# Altium schematic sheet DVT-Discrete-1.SchDoc(DVT-Discrete-1)
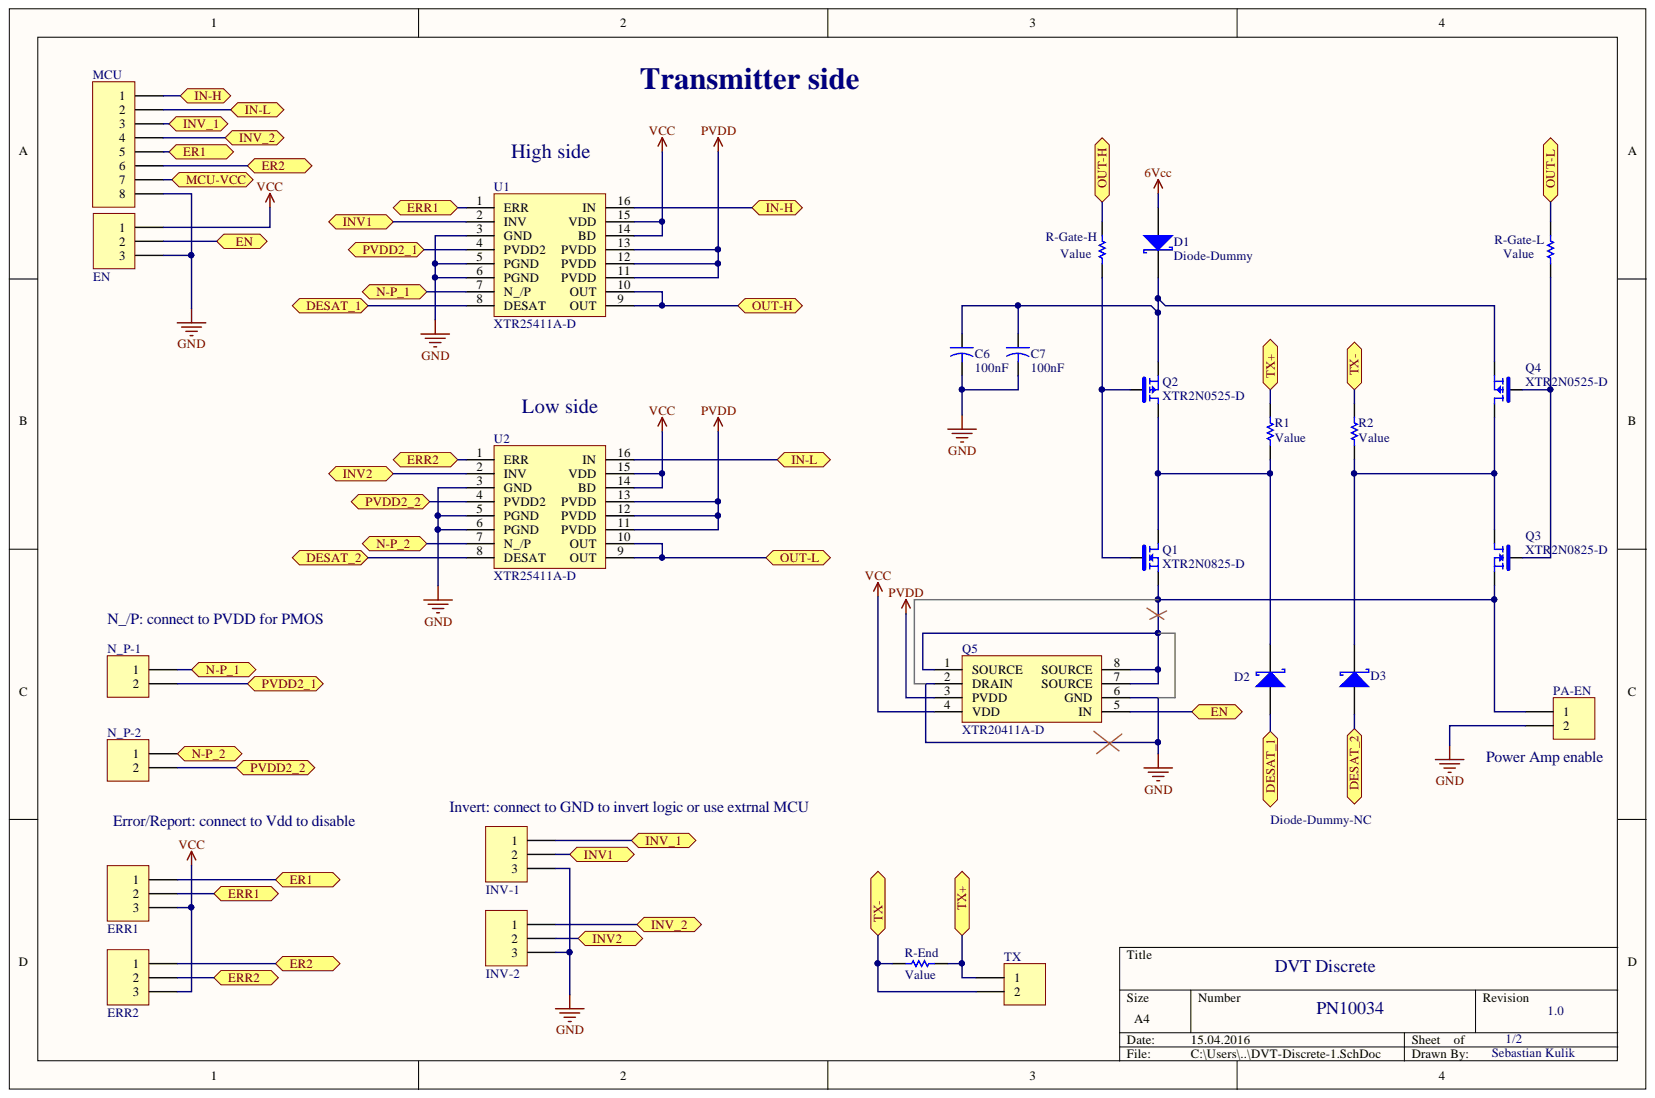
[diagram p0: DVT-Discrete-1.SchDoc]

## Parts
C6  value 100nF  [Dummy-10mm]  (hidden comment: Capacitor-Dummy-THT)
C7  value 100nF  [Dummy-10mm]  (hidden comment: Capacitor-Dummy-THT)
D1  Diode-Dummy  [DO-15-Dummy]
D2  Diode-Dummy-NC  [DO-15-Dummy]
D3  Diode-Dummy  [DO-15-Dummy]
EN  Plug_3_90_2.54  [HDR1X3]  (value not drawn)
ERR1  Plug_3_90_2.54  [HDR1X3]  (value not drawn)
ERR2  Plug_3_90_2.54  [HDR1X3]  (value not drawn)
INV-1  Plug_3_90_2.54  [HDR1X3]  (value not drawn)
INV-2  Plug_3_90_2.54  [HDR1X3]  (value not drawn)
MCU  Plug_08_2.54  [HDR1X8_2.54]  (value not drawn)
N_P-1  Plug_2_90_2.54  [HDR1X2]  (value not drawn)
N_P-2  Plug_2_90_2.54  [HDR1X2]  (value not drawn)
PA-EN  Plug_2_90_2.54  [HDR1X2]  (value not drawn)
Q1  XTR2N0825-D  [DIP-8-Ceramic-HT]
Q2  XTR2N0525-D  [DIP-8-Ceramic-HT]
Q3  XTR2N0825-D  [DIP-8-Ceramic-HT]
Q4  XTR2N0525-D  [DIP-8-Ceramic-HT]
Q5  XTR20411A-D  [DIP-8-Ceramic-HT]
R1  Resistor-Dummy-THT  [Dummy-15mm]  (value not drawn)
R2  Resistor-Dummy-THT  [Dummy-15mm]  (value not drawn)
R-End  Resistor-Dummy-THT  [Dummy-10mm]  (value not drawn)
R-Gate-H  Resistor-Dummy-THT  [Dummy-10mm]  (value not drawn)
R-Gate-L  Resistor-Dummy-THT  [Dummy-10mm]  (value not drawn)
TX  PHOENIX_1715721  [Phoenix-2-5_08]  (value not drawn)
U1  XTR25411A-D  [DIP-16-Ceramic-HT]
U2  XTR25411A-D  [DIP-16-Ceramic-HT]

## Ports
DESAT_1, DESAT_2, EN, ER1, ER2, ERR1, ERR2, IN-H, IN-L, INV1, INV2, INV_1, INV_2, MCU-VCC, N-P_1, N-P_2, OUT-H, OUT-L, PVDD2_1, PVDD2_2, TX+, TX-

## Nets
6VCC: D1.2
GND: C6.2 C7.2 EN.3 INV-1.3 INV-2.3 MCU.8 PA-EN.2 Q5.2(DRAIN) Q5.6(GND) U1.3(GND) U1.5(PGND) U1.6(PGND) U2.3(GND) U2.5(PGND) U2.6(PGND)
PVDD: Q5.3(PVDD) U1.11(PVDD) U1.12(PVDD) U1.13(PVDD) U2.11(PVDD) U2.12(PVDD) U2.13(PVDD)
VCC: EN.1 ERR1.3 ERR2.3 Q5.4(VDD) U1.14(BD) U1.15(VDD) U2.14(BD) U2.15(VDD)
DESAT_1: D2.2 U1.8(DESAT) ; port DESAT_1
DESAT_2: D3.2 U2.8(DESAT) ; port DESAT_2
EN: EN.2 Q5.5(IN) ; port EN
ER1: ERR1.1 MCU.5 ; port ER1
ER2: ERR2.1 MCU.6 ; port ER2
ERR1: ERR1.2 U1.1(ERR) ; port ERR1
ERR2: ERR2.2 U2.1(ERR) ; port ERR2
IN-H: MCU.1 U1.16(IN) ; port IN-H
IN-L: MCU.2 U2.16(IN) ; port IN-L
INV1: INV-1.2 U1.2(INV) ; port INV1
INV2: INV-2.2 U2.2(INV) ; port INV2
INV_1: INV-1.1 MCU.3 ; port INV_1
INV_2: INV-2.1 MCU.4 ; port INV_2
MCU-VCC: MCU.7 ; port MCU-VCC
N-P_1: N_P-1.1 U1.7(N_/P) ; port N-P_1
N-P_2: N_P-2.1 U2.7(N_/P) ; port N-P_2
OUT-H: R-Gate-H.2 U1.9(OUT) U1.10(OUT) ; port OUT-H
OUT-L: R-Gate-L.2 U2.9(OUT) U2.10(OUT) ; port OUT-L
PVDD2_1: N_P-1.2 U1.4(PVDD2) ; port PVDD2_1
PVDD2_2: N_P-2.2 U2.4(PVDD2) ; port PVDD2_2
NetC6_1: C6.1 C7.1 D1.1 Q2.1 Q4.1
NetD2_1: D2.1 Q1.5 Q2.5 R1.1
NetD3_1: D3.1 Q3.5 Q4.5 R2.1
NetPA-EN_1: PA-EN.1 Q1.1 Q3.1 Q5.1(SOURCE) Q5.7(SOURCE) Q5.8(SOURCE)
NetQ1_4: Q1.4 Q2.4 R-Gate-H.1
NetQ3_4: Q3.4 Q4.4 R-Gate-L.1
NetR1_2: R1.2 R-End.2 TX.1
NetR2_2: R2.2 R-End.1 TX.2

## Library data of the parts
C6 C7 = Capacitor-Dummy-THT: Deliverer PartNr: 699-5027; Manufacturer: AVX; Manufacturer PartNr: SR205E104MARTR; Deliverer: RS; Component Kind: Standard; Description: Capacitor-Dummy-THT; Library Name: Capacitors.IntLib; PackageDescription: -; Part Description: Capacitor-Dummy-THT; Published: 08.12.2015; Signal Integrity: Cap; Simulation: CAP; TDE-PN: -; Publisher: Sebastian Kulik
D1-D3 = Diode-Dummy: Deliverer PartNr: 906-6762; Manufacturer: COMCHIP TECHNOLOGY; Manufacturer PartNr: SB5100E-G; Deliverer: RS; Component Kind: Standard; Description: Diode-Dummy; Library Name: Discrete.IntLib; PackageDescription: DO-201AD; Published: 09.12.2015; Simulation: 18TQ045; TDE-PN: -; Publisher: Sebastian Kulik
EN ERR1 ERR2 INV-1 INV-2 = Plug_3_90_2.54: Plug,-3-Pin; Deliverer PartNr: 2356177; Manufacturer: WE; Manufacturer PartNr: 61300311021; Deliverer: Farnell; TDE-PN: CON0011; Component Kind: Standard; Library Name: Connectors.IntLib; Publisher: Sebastian Kulik
MCU = Plug_08_2.54: Plug, 08-Pin; Deliverer PartNr: 61300811121; Deliverer: WE; TDE-PN: CON0035; Component Kind: Standard; LatestRevisionDate: 11.11.2014; LatestRevisionNote: Re-released for DXP Platform.; Library Name: Connectors.IntLib; Manufacturer: WE; Manufacturer PartNr: 61300811121; Signal Integrity: Connector; Publisher: Sebastian Kulik
N_P-1 N_P-2 PA-EN = Plug_2_90_2.54: Plug, 2-Pin; Deliverer PartNr: 2356176; Manufacturer: WE; Manufacturer PartNr: 61300211021; Deliverer: Farnell; TDE-PN: CON0010; Component Kind: Standard; LatestRevisionDate: 14.05.2014; LatestRevisionNote: Re-released for DXP Platform.; Library Name: Connectors.IntLib; Signal Integrity: Connector; Publisher: Sebastian Kulik
Q1 Q3 = XTR2N0825-D: N-FET, 80V@ 4A; Manufacturer: TI; Deliverer: ProTEc; TDE-PN: DIS0043; Component Kind: Standard; Deliverer PartNr: XTR2N0825-D; Library Name: Discrete.IntLib; Manufacturer PartNr: XTR2N0825-D; PackageDescription: DIP-8-Ceramic-HT; Published: 09.12.2015; Publisher: Sebastian Kulik
Q2 Q4 = XTR2N0525-D: P-FET, 50V@ 4A; Manufacturer: TI; Deliverer: ProTEc; TDE-PN: DIS0044; Component Kind: Standard; Deliverer PartNr: XTR2N0525-D; Library Name: Discrete.IntLib; Manufacturer PartNr: XTR2N0525-D; PackageDescription: DIP-8-Ceramic-HT; Published: 09.12.2015; Publisher: Sebastian Kulik
Q5 = XTR20411A-D: Gate driver; Manufacturer: X-Rel; Deliverer: ProTec; TDE-PN: IC0068; Component Kind: Standard; Deliverer PartNr: XTR20411A-D; Library Name: ICs.IntLib; Manufacturer PartNr: XTR20411A-D; PackageDescription: DIP-8-Ceramic-HT; Published: 08.12.2015; Publisher: Sebastian Kulik
TX = 2Pol_1715721: Phoenix, 2 pol, 5.08mm, 22A, 715721; Deliverer PartNr: 193-0564; Deliverer: RS; TDE-PN: CON0008; Component Kind: Standard; LatestRevisionDate: 02.05.2014; LatestRevisionNote: Re-released for DXP Platform.; Library Name: Connectors.IntLib; Manufacturer: Phoenix; Manufacturer PartNr: 1715721; PackageDescription: Phoenix-2-5_08; Signal Integrity: Connector; Publisher: Sebastian Kulik
U1 U2 = XTR25411A-D: Gate driver; Manufacturer: X-Rel; Deliverer: ProTec; TDE-PN: IC0067; Component Kind: Standard; Deliverer PartNr: XTR25411A-D; Library Name: ICs.IntLib; Manufacturer PartNr: XTR25411A-D; PackageDescription: DIP-16-Ceramic-HT; Published: 08.12.2015; Publisher: Sebastian Kulik
R1 R2 R-End R-Gate-H R-Gate-L = Resistor-Dummy-THT: Component Kind: Standard; Deliverer: -; Deliverer PartNr: -; Description: Resistor-Dummy-THT; Library Name: Resistors.IntLib; Manufacturer: -; Manufacturer PartNr: -; PackageDescription: -; Part Desicription: Resistor-Dummy-THT; Published: 08.12.2015; Signal Integrity: Res; Simulation: RESISTOR; TDE-PN: -; Value: Value; Publisher: Sebastian Kulik
